# Altium schematic sheet dali.SchDoc
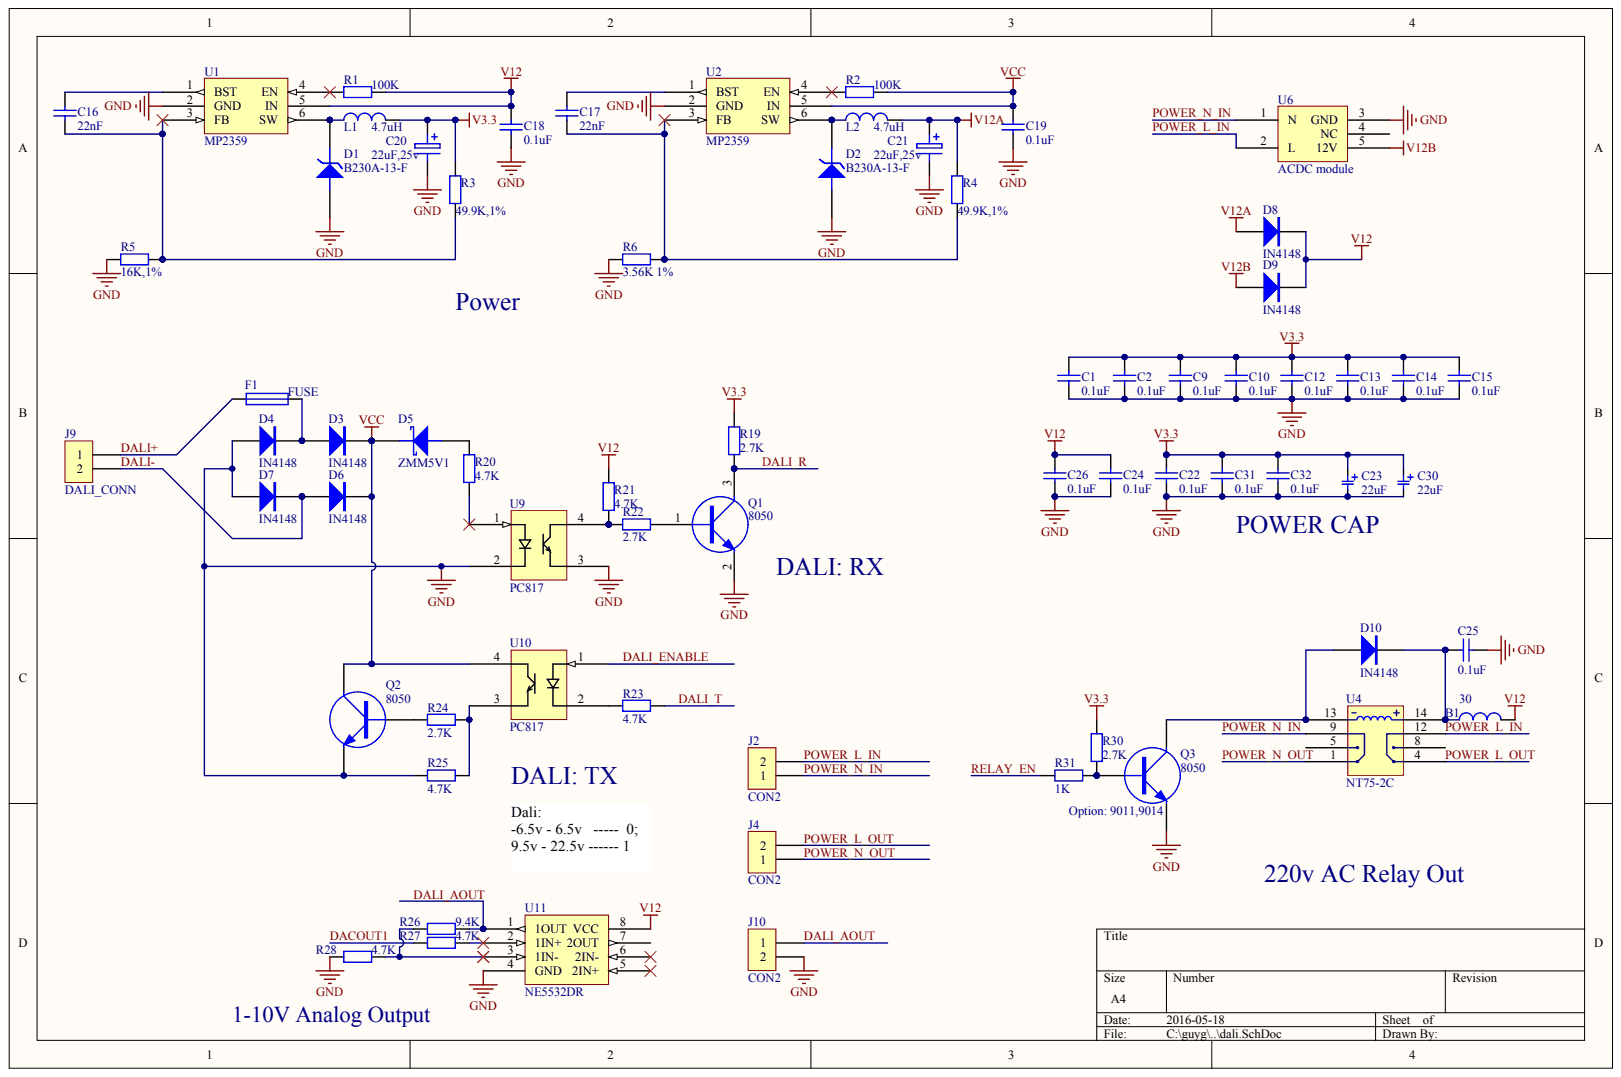
[diagram p0: dali.SchDoc]

## Parts
B1  30
C1  0.1uF
C2  0.1uF
C9  0.1uF
C10  0.1uF
C12  0.1uF
C13  0.1uF
C14  0.1uF
C15  0.1uF
C16  22nF
C17  22nF  value 0.1uF
C18  0.1uF
C19  0.1uF
C20  22uF,25v
C21  22uF,25v
C22  0.1uF
C23  22uF
C24  0.1uF
C25  0.1uF
C26  0.1uF
C30  22uF
C31  0.1uF
C32  0.1uF
D1  B230A-13-F
D2  B230A-13-F
D3  IN4148
D4  IN4148
D5  ZMM5V1
D6  IN4148
D7  IN4148
D8  IN4148
D9  IN4148
D10  IN4148
F1  FUSE
J2  CON2
J4  CON2
J9  DALI_CONN
J10  CON2
L1  4.7uH
L2  4.7uH
Q1  8050
Q2  8050
Q3  8050
R1  100K
R2  100K
R3  49.9K,1%
R4  49.9K,1%
R5  16K,1%
R6  3.56K 1%
R19  2.7K
R20  4.7K
R21  4.7K
R22  2.7K
R23  4.7K
R24  2.7K
R25  4.7K
R26  9.4K
R27  4.7K
R28  4.7K
R30  2.7K
R31  1K
U1  MP2359
U2  MP2359
U4  NT75-2C
U6  ACDC module
U9  PC817
U10  PC817
U11  NE5532DR

## Nets
GND: C1.2 C2.2 C9.2 C10.2 C12.2 C13.2 C14.2 C15.2 C18.2 C19.2 C20.2 C21.2 C22.2 C23.2 C24.2 C25.2 C26.2 C30.2 C31.2 C32.2 D1.1 D2.1 D4.A D7.A J10.2 Q1.2 Q2.2 Q3.2 R5.1 R6.1 R25.2 R28.2 U1.2(GND) U2.2(GND) U6.3(GND) U9.2 U9.3 U11.4(GND)
V3.3: C1.1 C2.1 C9.1 C10.1 C12.1 C13.1 C14.1 C15.1 C20.1 C22.1 C23.1 C30.1 C31.1 C32.1 L1.1 R3.1 R19.2 R30.1
V12: B1.1 C18.1 C24.1 C26.1 D8.K D9.K R1.1 R21.2 U1.5(IN) U11.8(VCC)
V12A: C21.1 D8.A L2.1 R4.1
V12B: D9.A U6.5(12V)
VCC: C19.1 D3.K D5.2 D6.K Q2.3 R2.1 U2.5(IN) U10.4
DACOUT1: R27.2
DALI+: F1.1 J9.1
DALI-: D6.A D7.K J9.2
DALI_AOUT: J10.1 R26.1 U11.1(1OUT)
DALI_ENABLE: U10.1
DALI_R: Q1.3 R19.1
DALI_T: R23.1
POWER_L_IN: J2.2 U4.12 U6.2(L)
POWER_L_OUT: J4.2 U4.4
POWER_N_IN: J2.1 U4.9 U6.1(N)
POWER_N_OUT: J4.1 U4.1
RELAY_EN: R31.2
NetB1_2: B1.2 C25.1 D10.K U4.14
NetC16_1: C16.1 R3.2 R5.2 U1.3(FB)
NetC16_2: C16.2 U1.1(BST)
NetC17_1: C17.1 R4.2 R6.2 U2.3(FB)
NetC17_2: C17.2 U2.1(BST)
NetD1_2: D1.2 L1.2 U1.6(SW)
NetD2_2: D2.2 L2.2 U2.6(SW)
NetD3_A: D3.A D4.K F1.2
NetD5_1: D5.1 R20.2
NetD10_A: D10.A Q3.3 U4.13
NetQ1_1: Q1.1 R22.1
NetQ2_1: Q2.1 R24.2
NetQ3_1: Q3.1 R30.2 R31.1
NetR1_2: R1.2 U1.4(EN)
NetR2_2: R2.2 U2.4(EN)
NetR20_1: R20.1 U9.1
NetR21_1: R21.1 R22.2 U9.4
NetR23_2: R23.2 U10.2
NetR24_1: R24.1 R25.1 U10.3
NetR26_2: R26.2 R28.1 U11.3(1IN-)
NetR27_1: R27.1 U11.2(1IN+)

Not connected: U4 5 8; U6 4(NC); U11 5(2IN+) 6(2IN-) 7(2OUT)

## Library data of the parts
C16 = (no symbol name): Value: 0.1uF; Published: 8-Jun-2000; LatestRevisionDate: 17-Jul-2002; LatestRevisionNote: Re-released for DXP Platform.; PackageReference: RAD-0.3; Publisher: Altium Limited
R1-R6 R19-R28 R30 = (no symbol name): Value: 1K; Published: 8-Jun-2000; LatestRevisionDate: 17-Jul-2002; LatestRevisionNote: Re-released for DXP Platform.; PackageReference: AXIAL-0.4; Publisher: Altium Limited
B1 C1 C2 C9 C10 C12-C15 C17-C26 C30-C32 D1-D10 F1 J2 J4 J9 J10 L1 L2 Q1-Q3 R31 U1 U2 U4 U6 U9-U11 = (no symbol name)
  C1 C2 C9 C10 C12-C15 C18 C19 C22 C24-C26 C31 C32: Published: 8-Jun-2000; LatestRevisionDate: 17-Jul-2002; LatestRevisionNote: Re-released for DXP Platform.; PackageReference: RAD-0.3; Value: 0.1uF
  C17: Published: 8-Jun-2000; LatestRevisionDate: 17-Jul-2002; LatestRevisionNote: Re-released for DXP Platform.; PackageReference: RAD-0.3
  R31: Published: 8-Jun-2000; LatestRevisionDate: 17-Jul-2002; LatestRevisionNote: Re-released for DXP Platform.; PackageReference: AXIAL-0.4; Value: 1K
  C1 C2 C9 C10 C12-C15 C17-C19 C22 C24-C26 C31 C32 R31: Publisher: Altium Limited
